# Altium schematic sheet interface.SchDoc
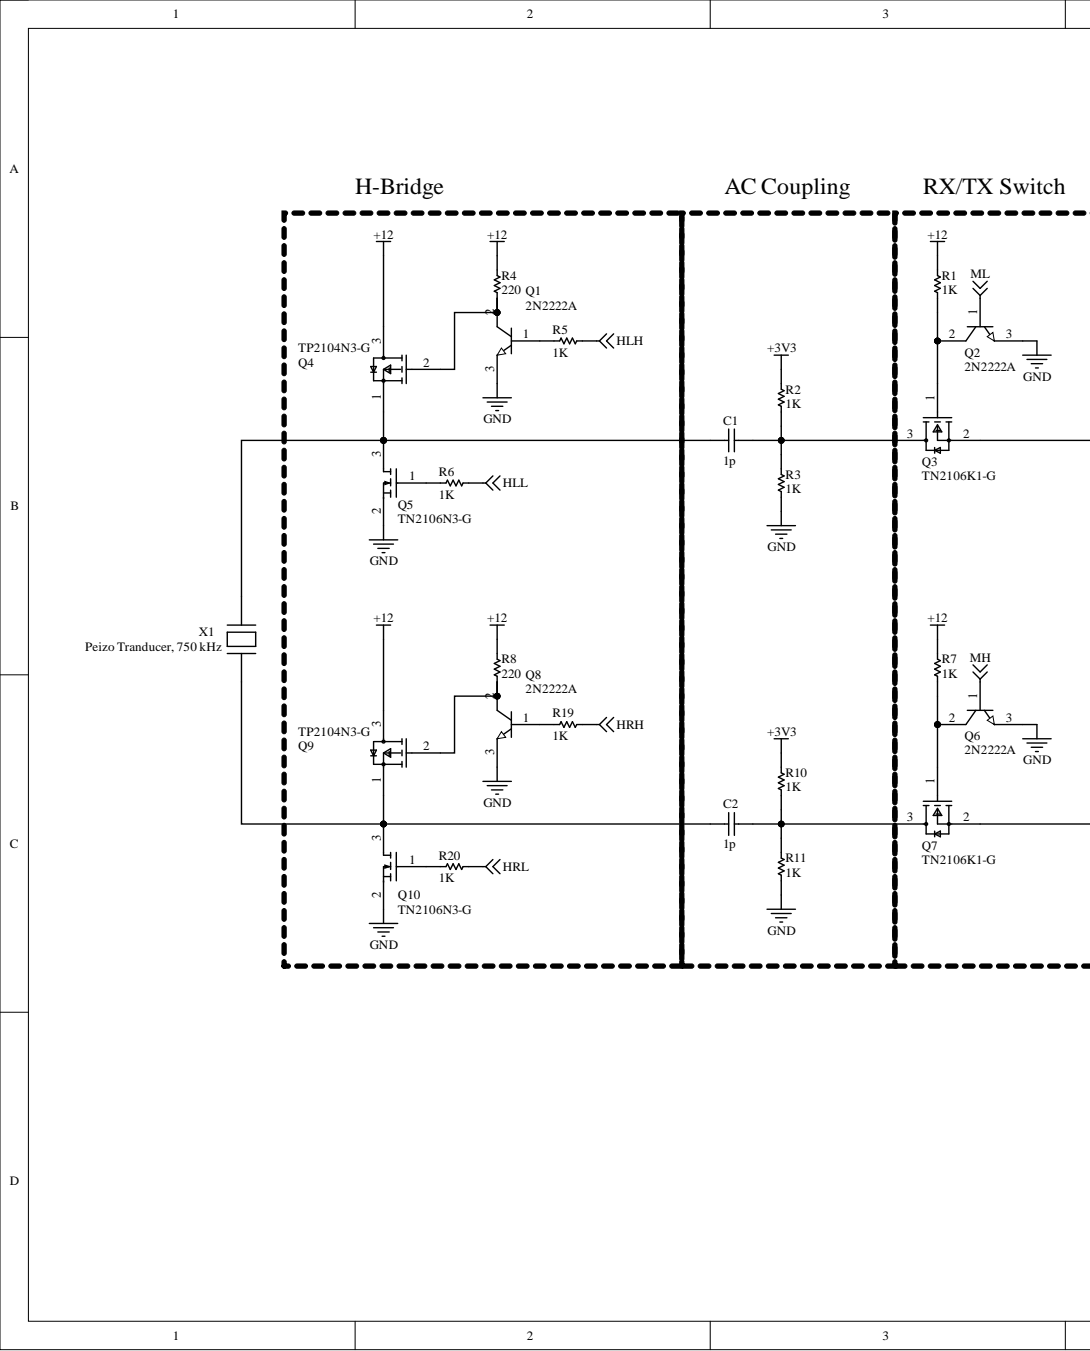
[diagram p0: interface.SchDoc, left]
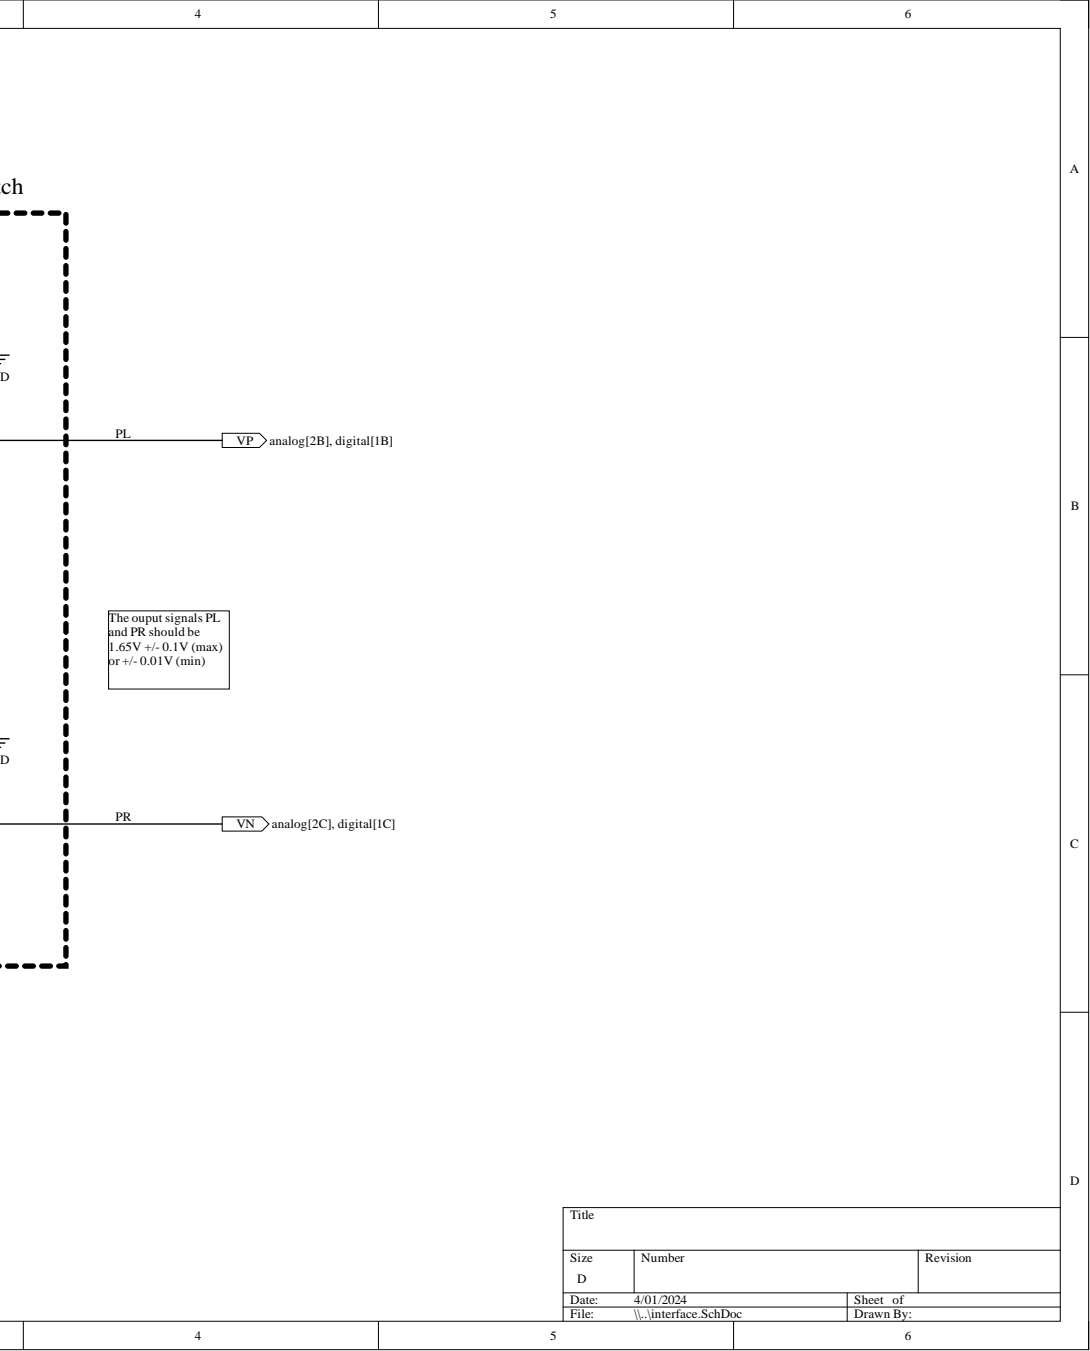
[diagram p1: interface.SchDoc, right]

## Parts
C1  value 1p  (hidden comment: Capacitor)
C2  value 1p  (hidden comment: Capacitor)
Q1  2N2222A  [STM-TO-18-3]
Q2  2N2222A  [STM-TO-18-3]
Q3  TN2106K1-G  [FP-K1-T-IPC_A]
Q4  TP2104N3-G  [FP-TP2104N3-G-MFG]
Q5  TN2106N3-G
Q6  2N2222A  [STM-TO-18-3]
Q7  TN2106K1-G  [FP-K1-T-IPC_A]
Q8  2N2222A  [STM-TO-18-3]
Q9  TP2104N3-G  [FP-TP2104N3-G-MFG]
Q10  TN2106N3-G
R1  value 1K  (hidden comment: Resistor)
R2  value 1K  (hidden comment: Resistor)
R3  value 1K  (hidden comment: Resistor)
R4  value 220  (hidden comment: Resistor)
R5  value 1K  (hidden comment: Resistor)
R6  value 1K  (hidden comment: Resistor)
R7  value 1K  (hidden comment: Resistor)
R8  value 220  (hidden comment: Resistor)
R10  value 1K  (hidden comment: Resistor)
R11  value 1K  (hidden comment: Resistor)
R19  value 1K  (hidden comment: Resistor)
R20  value 1K  (hidden comment: Resistor)
X1  Peizo Tranducer, 750 kHz

## Ports
VN, VP

## Nets
+3V3: R2.2 R10.2
+12: Q4.3 Q9.3 R1.2 R4.2 R7.2 R8.2
GND: Q1.3 Q2.3 Q5.2 Q6.3 Q8.3 Q10.2 R3.1 R11.1
HLH: R5.1
HLL: R6.1
HRH: R19.1
HRL: R20.1
MH: Q6.1
ML: Q2.1
PL: Q3.2 ; port VP
PR: Q7.2 ; port VN
NetC1_1: C1.1 Q4.1 Q5.3 X1.1
NetC1_2: C1.2 Q3.3 R2.1 R3.2
NetC2_1: C2.1 Q9.1 Q10.3 X1.2
NetC2_2: C2.2 Q7.3 R10.1 R11.2
NetQ1_1: Q1.1 R5.2
NetQ1_2: Q1.2 Q4.2 R4.1
NetQ2_2: Q2.2 Q3.1 R1.1
NetQ5_1: Q5.1 R6.2
NetQ6_2: Q6.2 Q7.1 R7.1
NetQ8_1: Q8.1 R19.2
NetQ8_2: Q8.2 Q9.2 R8.1
NetQ10_1: Q10.1 R20.2

## Library data of the parts
C1 C2 = Capacitor: SimulationGenericComponent: True; Component Kind: Standard; Description: Capacitor; Library Name: Simulation Generic Components.IntLib; Simulation: C_Capacitor_Default
Q1 Q2 Q6 Q8 = CMP-2000-05381-1: High Speed Switch, 0.6 A IC, -65 to 175 degC, 3-Pin TO-18, RoHS, Bulk; Manufacturer: STMicroelectronics; Collector Emitter Voltage V(br)ceo: 40V; DC Collector Current: 600mA; DC Current Gain hFE: 300hFE; No. of Pins: 3Pins; Power Dissipation Pd: 500mW; Transistor Polarity: NPN; Transition Frequency ft: 300MHz; Automotive Qualification Standard: -; Component Kind: Standard; Datasheet URL: https://datasheet.ciiva.com/25917/cd00003223-25917094.pdf; Datasheet Version: Feb-03; Library Name: Altium Content Vault; Manufacturer URL: http://www.st.com/; Operating Temperature Max: 175degC; Package Description: 3-Pin Through Hole Device, Dia 5.8 mm, Pitch 1.27 mm; Package Reference: TO-18-3; Product Range: -; Transistor Case Style: TO-18
Q3 Q7 = CMP-06369-000018-1: MOSFET N-CH 60V 280MA SOT23-3; Drain to Source Resistance: 2.5R; Element Configuration: Single; Fall Time: 5ns; Gate to Source Voltage (Vgs): 20V; Input Capacitance: 50pF; Max Power Dissipation: 360mW; Number of Channels: 1; Polarity: N-CHANNEL; Turn-Off Delay Time: 6ns; Turn-On Delay Time: 3ns; Case/Package: SOT-23; Component Kind: Standard; Contact Plating: Tin; Continuous Drain Current (ID): 280mA; Drain to Source Breakdown Voltage: 60V; Height: 0.95mm; Length: 2.9mm; Library Name: Altium Content Vault; Max Operating Temperature: 150°C; Min Operating Temperature: -55°C; Mount: Surface Mount; Number of Elements: 1; Number of Pins: 3; Package Quantity: 3000; Packaging: Tape and Reel; Power Dissipation: 360mW; Radiation Hardening: No; Rds On Max: 2.5R; Rise Time: 5ns; Series: -; Weight: 0.050717oz; Width: 1.3mm
Q4 Q9 = CMP-06369-000017-1: MOSFET P-CH 40V 175MA TO92-3; Drain to Source Breakdown Voltage: -40V; Drain to Source Resistance: 6R; Element Configuration: Single; Fall Time: 4ns; Gate to Source Voltage (Vgs): 20V; Input Capacitance: 60pF; Max Power Dissipation: 740mW; Number of Channels: 1; Polarity: P-CHANNEL; Turn-Off Delay Time: 5ns; Case/Package: TO-92-3; Component Kind: Standard; Continuous Drain Current (ID): 175mA; Drain to Source Voltage (Vdss): 40V; Height: 5.33mm; Lead Free: Lead Free; Length: 5.21mm; Library Name: Altium Content Vault; Max Operating Temperature: 150°C; Min Operating Temperature: -55°C; Mount: Through Hole; Number of Pins: 3; Package Quantity: 1000; Packaging: Bulk; Power Dissipation: 740mW; Rds On Max: 6R; Rise Time: 4ns; RoHS Compliant: Yes; Series: -; Turn-On Delay Time: 4ns; Weight: 0.016oz; Width: 4.19mm
Q5 Q10 = TN2106N3-G: Small Signal Field-Effect Transistor, 1.2A I(D), 100V, 1-Element, N-Channel, Silicon, Metal-oxide Semiconductor FET, TO-92; Manufacturer: Microchip Supertex; Drain Current-Max (Abs) (ID): 300mA; Drain-source On Resistance-Max: 2.5R; DS Breakdown Voltage-Min: 60V; Feedback Cap-Max (Crss): 8pF; Number of Elements: 1; Operating Mode: ENHANCEMENT MODE; Package Body Material: Plastic; Power Dissipation-Max (Abs): 740mW; Qualification Status: Not Qualified; Surface Mount: No; Transistor Application: SWITCHING; Category: MOSFETs; Component Kind: Standard; ComponentLink1Description: https://datasheet.ciiva.com:443/pdfs/VipMasterIC/IC/SUPR/SUPRS03953/SUPRS03953-1.pdf?src-supplier=IHS+Markit; ComponentLink1URL: https://datasheet.ciiva.com:443/pdfs/VipMasterIC/IC/SUPR/SUPRS03953/SUPRS03953-1.pdf?src-supplier=IHS+Markit; ComponentLink2Description: https://datasheet.ciiva.com/16232/tn2106-16232278.pdf?src-supplier=Onlinecomponents.com; ComponentLink2URL: https://datasheet.ciiva.com/16232/tn2106-16232278.pdf?src-supplier=Onlinecomponents.com; ComponentLink3Description: https://datasheet.ciiva.com/30180/14tn2106-30180231.pdf?src-supplier=Onlinecomponents.com; ComponentLink3URL: https://datasheet.ciiva.com/30180/14tn2106-30180231.pdf?src-supplier=Onlinecomponents.com; Drain Current-Max (ID): 300mA; FET Technology: METAL-OXIDE SEMICONDUCTOR; JEDEC-95 Code: TO-92; JESD-30 Code: O-PBCY-T3; JESD-609 Code: e3; Manufacturer Part Number: TN2106N3-G; Max Operating Temperature: 150°C; Package Shape: Round; Package Style: CYLINDRICALMeter; Pins: 3; Polarity/Channel Type: N-CHANNEL; Pricing: 1=0.68, 25=0.56, 100=0.52 (USD); Reach SVHC Compliant: Yes; RoHS: Yes; RoHS Compliant: Yes; Stock: 1423; Supplier: Digikey; Supplier Part Number: TN2106N3-G-ND; Terminal Finish: Tin; Terminal Form: Through Hole; Terminal Position: BOTTOM; Transistor Element Material: SILICON; Library Name: dvl.SchLib
R1-R8 R10 R11 R19 R20 = Resistor: SimulationGenericComponent: True; TC1: 0; Component Kind: Standard; Description: Resistor; Library Name: Simulation Generic Components.IntLib; Simulation: R_Resistor_Default; TC2: 0
X1 = SMD20T27F750S: Component Kind: Standard; Library Name: dvl.SchLib
